# Altium schematic sheet GPS.SchDoc(GPS)
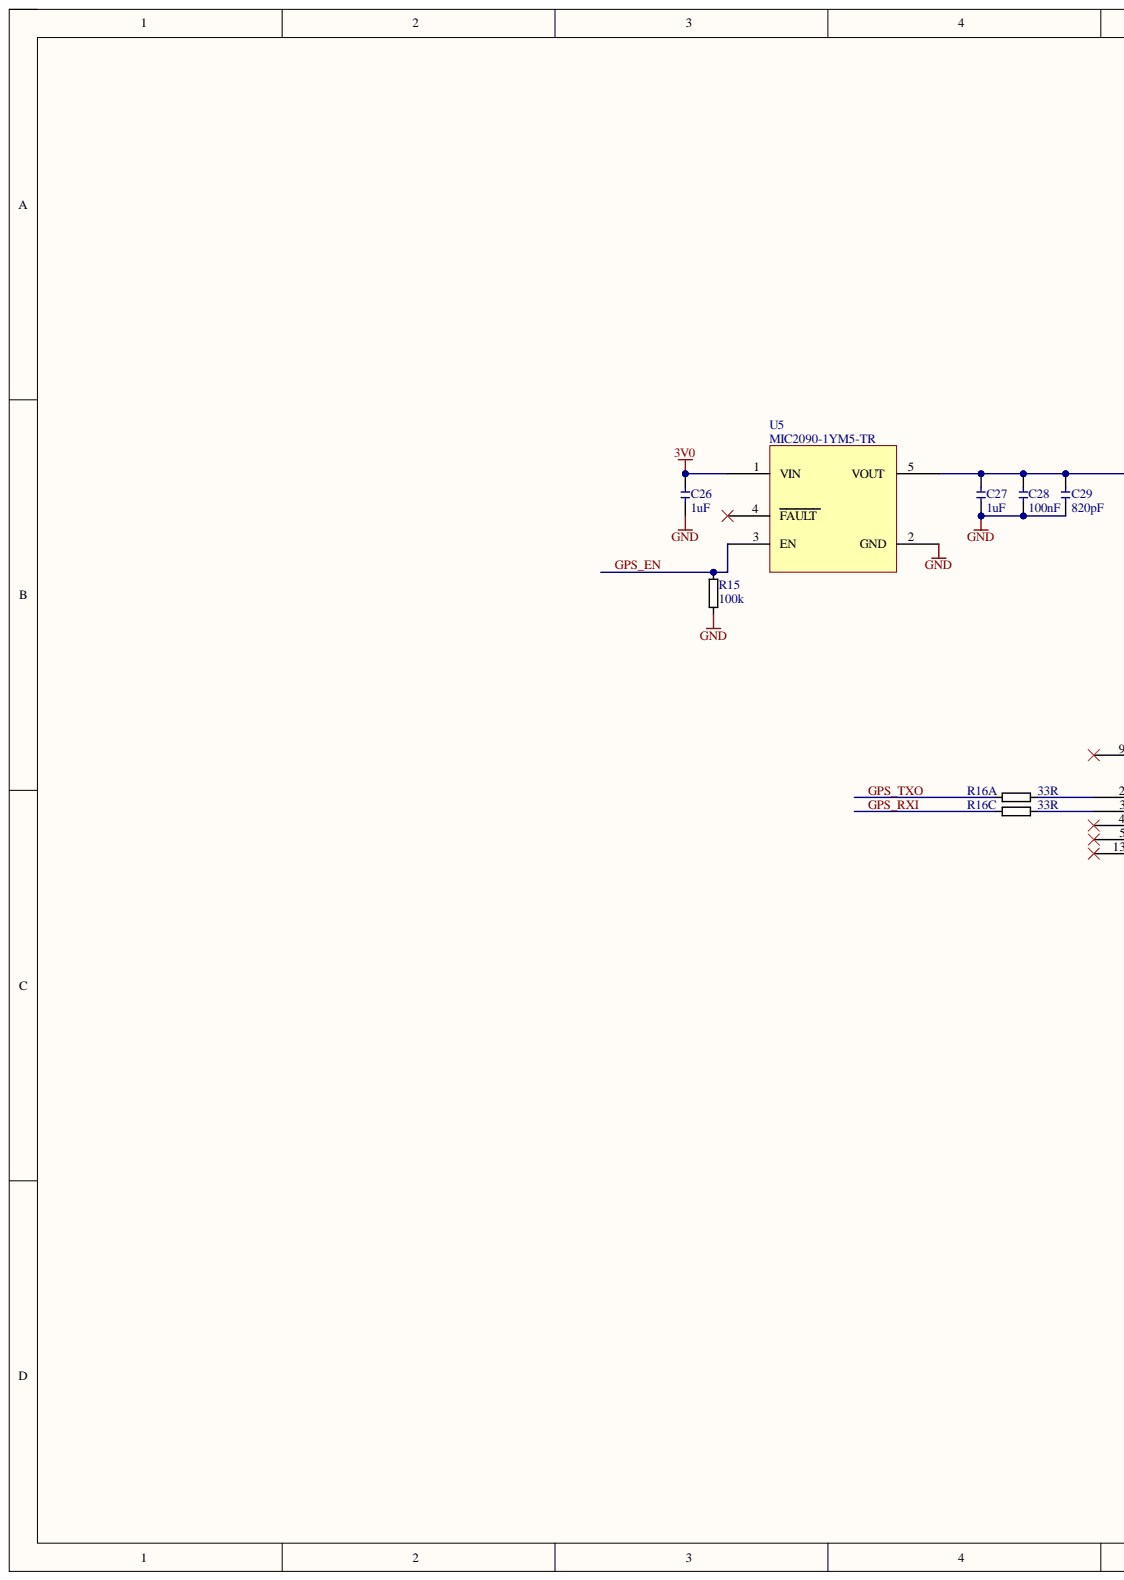
[diagram p0: GPS.SchDoc, left]
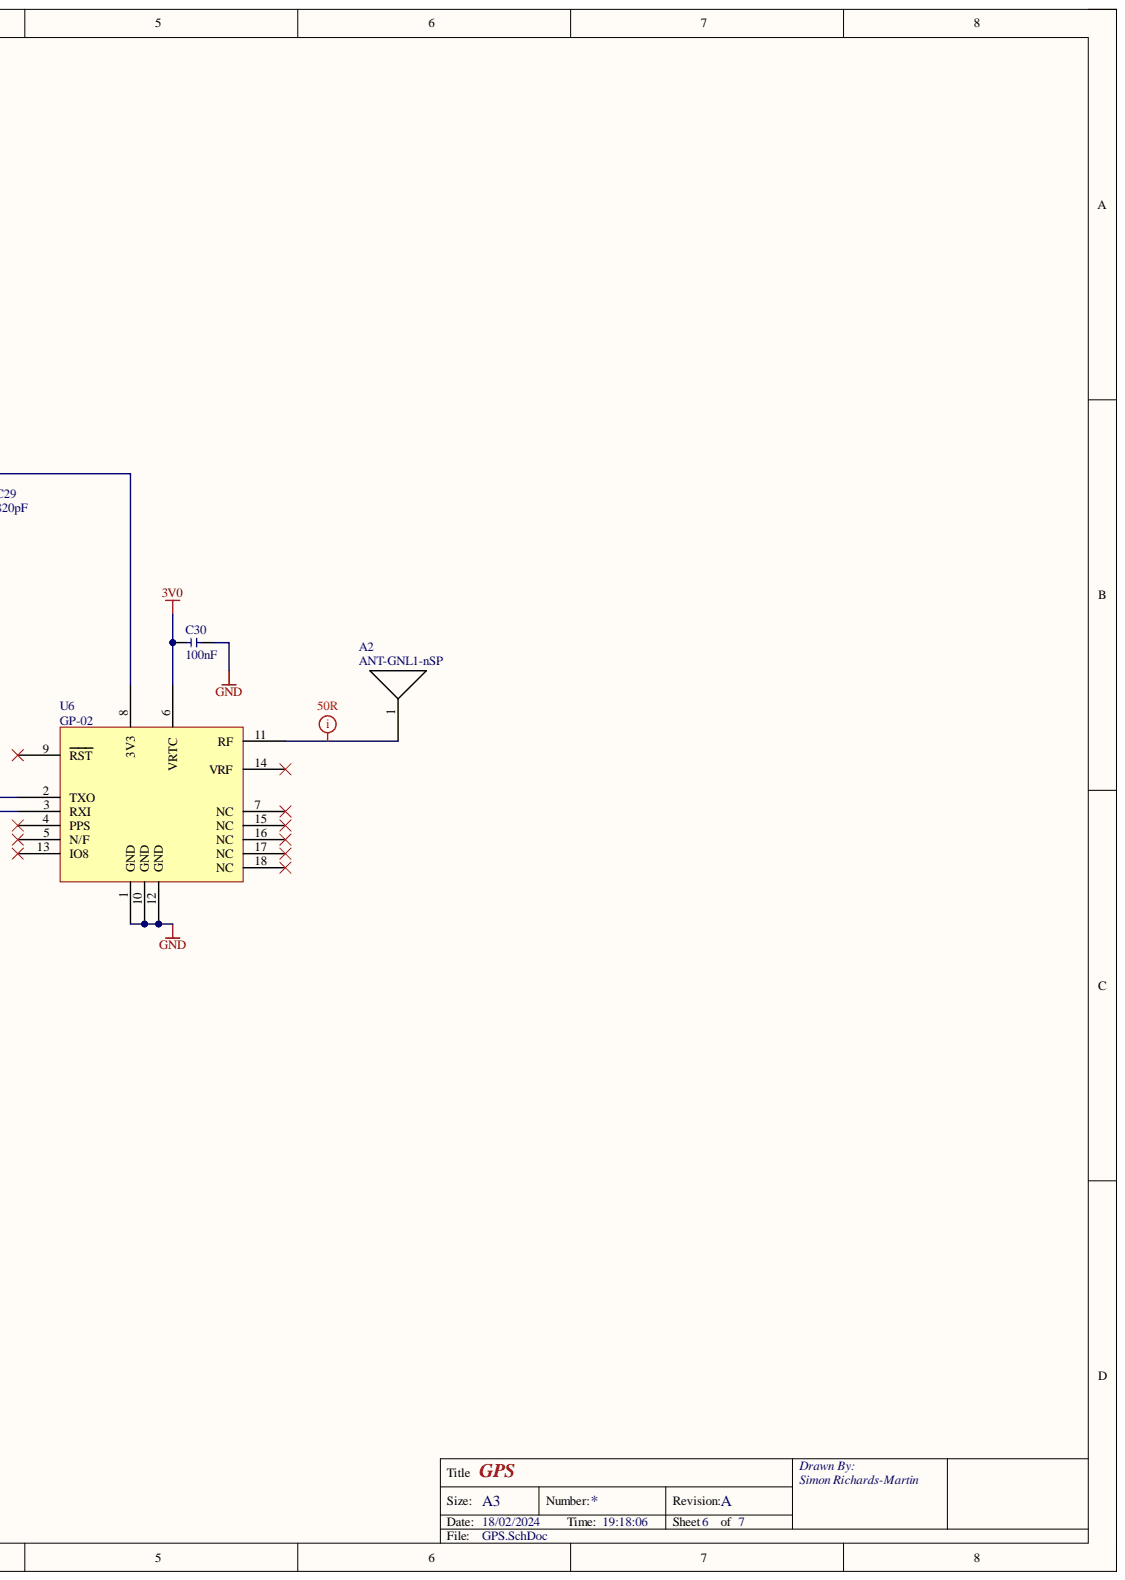
[diagram p1: GPS.SchDoc, right]

## Parts
A2  ANT-GNL1-nSP  [ANT-GNL1-nSP]
C26  1uF  [CHIP-0402]
C27  1uF  [CHIP-0402]
C28  100nF  [CHIP-0402]
C29  820pF  [CHIP-0402]
C30  100nF  [CHIP-0402]
R15  100k  [CHIP-0402]
R16  33R  [CAY10]  (parts A,C)
U5  MIC2090-1YM5-TR  [SOT23-5]
U6  GP-02  [GP-02]

## Nets
3V0: C26.1 C30.1 U5.1(VIN) U6.6(VRTC)
GND: C26.2 C27.2 C28.2 C29.2 C30.2 R15.1 U5.2(GND) U6.1(GND) U6.10(GND) U6.12(GND)
GPS_EN: R15.2 U5.3(EN)
GPS_RXI: R16.3
GPS_TXO: R16.1
NetA2_1: A2.1 U6.11(RF)
NetC27_1: C27.1 C28.1 C29.1 U5.5(VOUT) U6.8(3V3)
NetR16_6: R16.6 U6.3(RXI)
NetR16_8: R16.8 U6.2(TXO)

Not connected: U5 4(FAULT); U6 4(PPS) 5(N/F) 7(NC) 9(RST) 13(IO8) 14(VRF) 15(NC) 16(NC) 17(NC) 18(NC)

## Library data of the parts
A2 = ANT-GNL1-nSP: Antenna; Manufacturer: Linx Technologies / TE Connectivity; Component Kind: Standard; MPN: ANT-GNL1-nSP; Library Name: Paramotor Sensor Main.SchLib
C26 C27 = Capacitor 1uF 50V 0402 X5R: Manufacturer: Murata; MPN: GRM155R61H105KE05D; Component Kind: Standard; Description: Capacitor 1uF 50V 0402 X5R; Library Name: Paramotor Sensor Main.SchLib
C28 C30 = Capacitor 100nF 50V 0402 X5R: Manufacturer: Murata; MPN: GRM155R61H104KE14D; Component Kind: Standard; Description: Capacitor 100nF 50V 0402 X5R; Library Name: Paramotor Sensor Main.SchLib
C29 = Capacitor 820pF 50V 0402 C0G: Capacitor 820pF 50V 0402 X5R; Manufacturer: Samsung Electro-Mechanics; MPN: CL05C821JB5NNNC; Component Kind: Standard; Library Name: Paramotor Sensor Main.SchLib
R15 = Resistor 100k 1% 0402: Manufacturer: Yageo; MPN: RC0402FR-07100KL; Component Kind: Standard; Description: Resistor 100k 1% 0402; Library Name: Paramotor Sensor Main.SchLib
R16 = Resistor 4 Array 33R 5% 0804: Manufacturer: Bourns; MPN: CAY10-330J4LF; Component Kind: Standard; Description: Resistor 4 Array 33R 5% 0804; Library Name: Paramotor Sensor Main.SchLib
U5 = MIC2090-1YM5-TR: Load Switch Current Limit 50mA SOT23-5; Manufacturer: Microchip; Component Kind: Standard; MPN: MIC2090-1YM5-TR; Library Name: Paramotor Sensor Main.SchLib
U6 = GP-02: GPS/BDS/GNSS Receiver Module; Manufacturer: AI-Thinker; Component Kind: Standard; MPN: GP-02; Library Name: Paramotor Sensor Main.SchLib
